# Altium schematic sheet schematic.SchDoc
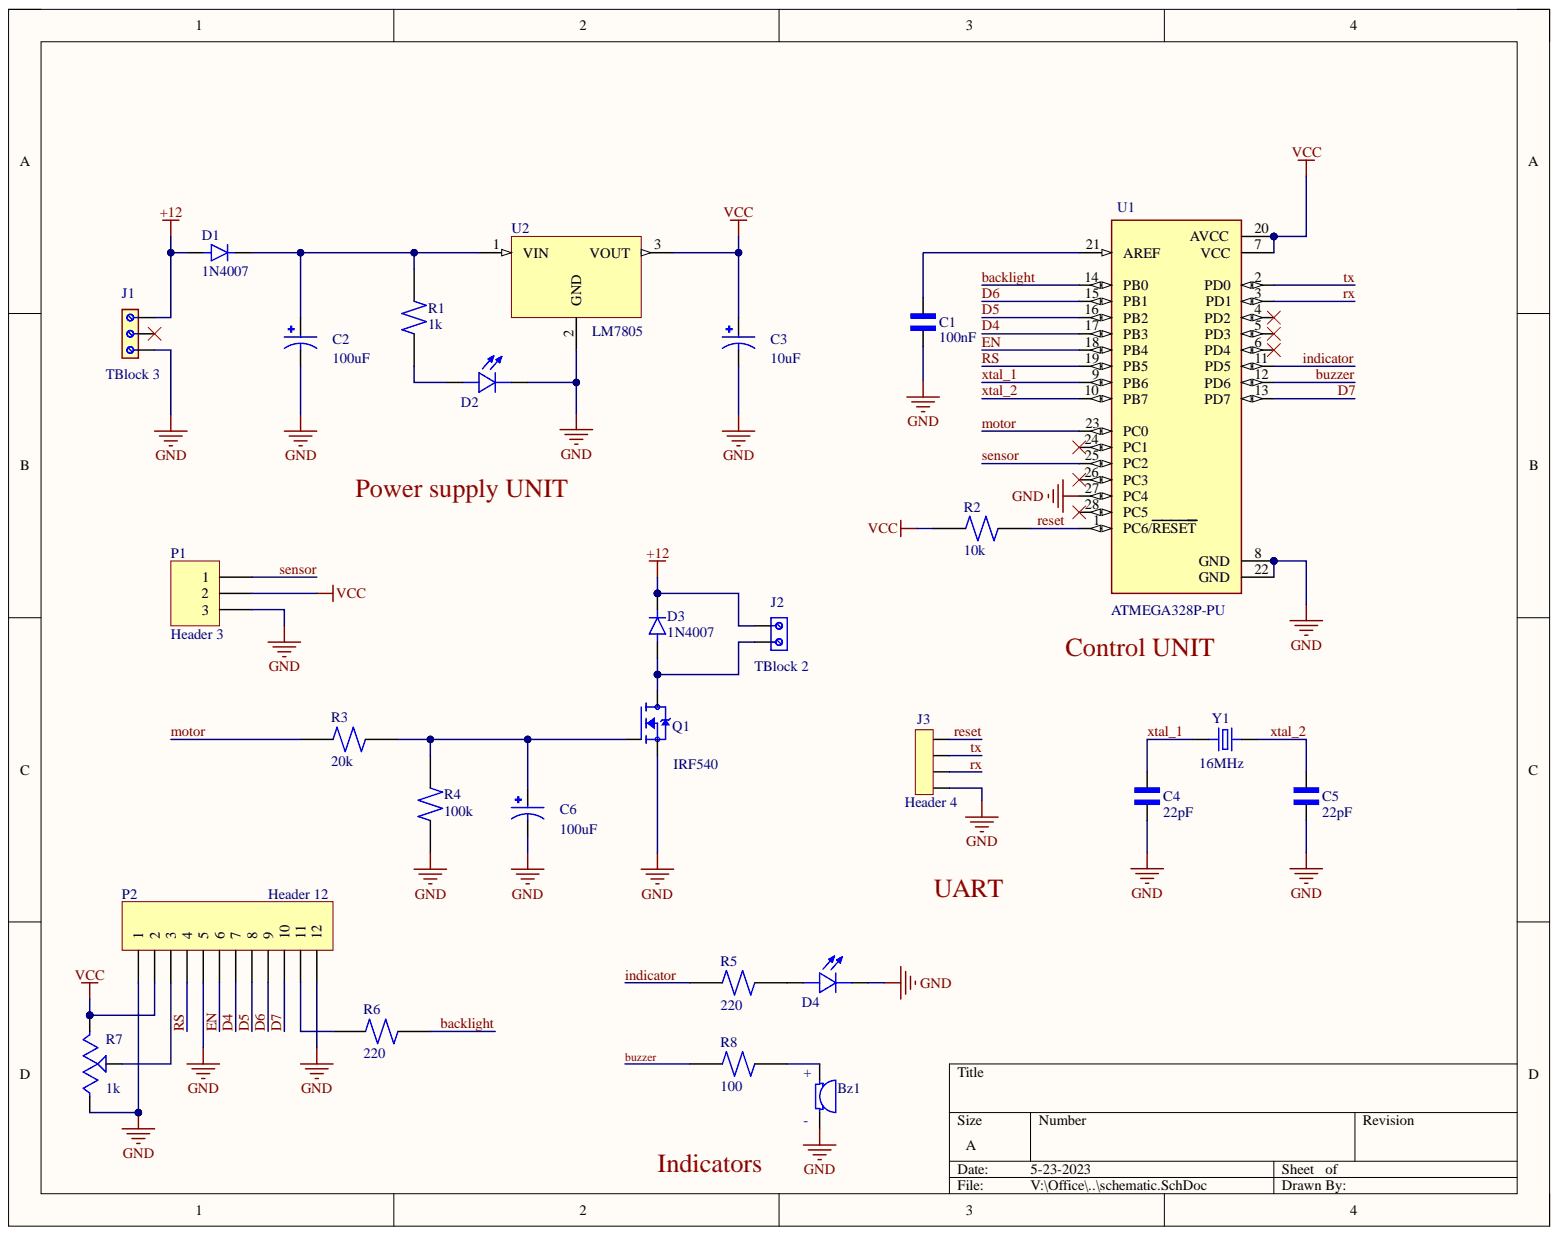
[diagram p0: schematic.SchDoc]

## Parts
Bz1  AI-1223-TWT-12V-R  [XDCR_AI-1223-TWT-12V-R]  (value not drawn)
C1  100nF  [CAPRB500W50L450T300H550]
C2  100uF  [CAPPRD500W50D500H1100]
C3  10uF  [CAPPRD500W50D500H1100]
C4  22pF  [CAPRB500W50L450T300H550]
C5  22pF  [CAPRB500W50L450T300H550]
C6  100uF  [CAPPRD500W50D500H1100]
D1  1N4007  [DIOAD1060W80L520D270]
D2  L08R5000Q1  [LEDRD254W57D500H1070]  (value not drawn)
D3  1N4007  [DIOAD1060W80L520D270]
D4  L08R5000Q1  [LEDRD254W57D500H1070]  (value not drawn)
J1  TBlock 3  [Terminal block - 3Pins]
J2  TBlock 2  [691111710002]
J3  Header 4  [SULLINS_PPTC041LFBN-RC]
P1  Header 3  [HDR1X3]
P2  Header 12  [HDR1X12]
Q1  IRF540  [TO254P1052X465X1989-3]
R1  1k  [RESAD1100W55L680D260]
R2  10k  [RESAD1100W55L680D260]
R3  20k  [RESAD1100W55L680D260]
R4  100k  [RESAD1100W55L680D260]
R5  220  [RESAD1100W55L680D260]
R6  220  [RESAD1100W55L680D260]
R7  1k  [TRIM_3306F-1-105]
R8  100  [RESAD1100W55L680D260]
U1  ATMEGA328P-PU  [DIP794W46P254L2967H457Q28B]
U2  LM7805  [TO255P1020X450X1968-3]
Y1  16MHz  [XTAL_ECS-160-20-4X]

## Nets
+12: D1.A D3.C J1.3 J2.1
GND: Bz1.N C1.2 C2.N C3.N C4.2 C5.2 C6.N D2.C D4.C J1.1 J3.4 P1.3 P2.1 P2.5 P2.12 Q1.3 R4.1 R7.1 U1.8(GND) U1.22(GND) U1.27(PC4) U2.2
VCC: C3.P P1.2 P2.2 R2.2 R7.3 U1.7(VCC) U1.20(AVCC) U2.3
backlight: R6.2 U1.14(PB0)
buzzer: R8.2 U1.12(PD6)
D4: P2.7 U1.17(PB3)
D5: P2.8 U1.16(PB2)
D6: P2.9 U1.15(PB1)
D7: P2.10 U1.13(PD7)
EN: P2.6 U1.18(PB4)
indicator: R5.2 U1.11(PD5)
motor: R3.2 U1.23(PC0)
reset: J3.1 R2.1 U1.1(PC6/RESET)
RS: P2.4 U1.19(PB5)
rx: J3.3 U1.3(PD1)
sensor: P1.1 U1.25(PC2)
tx: J3.2 U1.2(PD0)
xtal_1: C4.1 U1.9(PB6) Y1.1
xtal_2: C5.1 U1.10(PB7) Y1.2
NetBz1_P: Bz1.P R8.1
NetC1_1: C1.1 U1.21(AREF)
NetC2_P: C2.P D1.C R1.2 U2.1
NetC6_P: C6.P Q1.1 R3.1 R4.2
NetD2_A: D2.A R1.1
NetD3_A: D3.A J2.2 Q1.2
NetD4_A: D4.A R5.1
NetP2_3: P2.3 R7.2
NetP2_11: P2.11 R6.1

Not connected: J1 2; U1 4(PD2) 5(PD3) 6(PD4) 24(PC1) 26(PC3) 28(PC5)

## Library data of the parts
Bz1 = Buzzer: ELECTRO-MECHANICAL AUDIO INDICATOR; MF: PUI Audio,; Availability: In Stock; Component Kind: Standard; MP: AI-1223-TWT-12V-R; Package: 2225 PUI Audio; Price: None; Purchase-URL: https://pricing.snapeda.com/search/part/AI-1223-TWT-12V-R/?ref=eda; Library Name: Installed library.IntLib
C1 C4 C5 = Ceramic capacitor: 2200 pF Â±10% 250V Ceramic Capacitor X7R Radial; MF: TDK; MP: FG24X7R2E222KNT06; Check_prices: https://www.snapeda.com/parts/FG24X7R2E222KNT06/TDK/view-part/?ref=eda; Component Kind: Standard; Digi-Key_Part_Number: 445-173386-1-ND; Package: Radial TDK; Purchase-URL: https://pricing.snapeda.com/search/part/FG24X7R2E222KNT06/?ref=eda; SnapEDA_Link: https://www.snapeda.com/parts/FG24X7R2E222KNT06/TDK/view-part/?ref=snap; Library Name: Installed library.IntLib
C2 C3 C6 = Electrolytic capacitor: FS-A; MF: {'manufacturer': u'Panasonic'}; MP: EEUFS0J221B; Availability: Unavailable; Component Kind: Standard; Package: None; Price: None; Library Name: Installed library.IntLib
D1 D3 = 1N4007: Diode Switching 1KV 1A 2-Pin DO-41; Availability: Unavailable; Component Kind: Standard; Library Name: Components.IntLib; MP: 1N4007; Package: None; Price: None
D2 D4 = LED: LED, Orange, Through Hole, T-1 3/4 (5mm), 30 mA, 2.1 V, 635 nm; MF: {'manufacturer': u'LED Technology'}; Availability: Unavailable; Component Kind: Standard; MP: L08R5000Q1; Package: None; Price: None; Library Name: Installed library.IntLib
J1 = 3Pins Terminal block: 5.08 MM TERMINAL BLOCK;; MF: Wurth Electronics; MP: 691216510003S; Availability: In Stock; Check_prices: https://www.snapeda.com/parts/691216510003S/Wurth+Electronics+Inc/view-part/?ref=eda; Component Kind: Standard; Package: None; Price: None; Purchase-URL: https://pricing.snapeda.com/search/part/691216510003S/?ref=eda; SnapEDA_Link: https://www.snapeda.com/parts/691216510003S/Wurth+Electronics+Inc/view-part/?ref=snap; Library Name: Installed library.IntLib
J2 = Terminal Block - 2Pins: 2 Position Wire to Board Terminal Block Horizontal with Board 0.197 _5.00mm_ Through Hole; MF: Wurth Electronics; Availability: Unavailable; Component Kind: Standard; MP: 691111710002; Package: None; Price: None; SnapEDA_Link: https://www.snapeda.com/parts/691111710002/Wurth%20Electronics%20Inc./view-part/1195092/?ref=snap; Library Name: Installed library.IntLib
J3 = Female header - 4Pins: 4 Position Header Connector Through Hole; MF: Sullins Connector; MP: PPTC041LFBN-RC; Availability: Warning; Check_prices: https://www.snapeda.com/parts/PPTC041LFBN-RC/Sullins%20Connector%20Solutions/view-part/285917/?ref=eda; Component Kind: Standard; Package: None; Price: None; Purchase-URL: https://pricing.snapeda.com/search/part/PPTC041LFBN-RC/?ref=eda; SnapEDA_Link: https://www.snapeda.com/parts/PPTC041LFBN-RC/Sullins%20Connector%20Solutions/view-part/285917/?ref=snap; Library Name: Installed library.IntLib
P1 = Header 3: Header, 3-Pin; Component Kind: Standard; LatestRevisionDate: 17-Jul-2002; LatestRevisionNote: Re-released for DXP Platform.; Library Name: Miscellaneous Connectors.IntLib; Signal Integrity: Connector; Publisher: Altium Limited
P2 = Header 12: Header, 12-Pin; Component Kind: Standard; LatestRevisionDate: 17-Jul-2002; LatestRevisionNote: Re-released for DXP Platform.; Library Name: Miscellaneous Connectors.IntLib; Signal Integrity: Connector; Publisher: Altium Limited
Q1 = IRF540: N-Channel 100V 22A (Tc) 85W (Tc) Through Hole TO-220AB; Availability: Unavailable; Component Kind: Standard; MP: IRF540; Package: None; Price: None; Library Name: Installed library.IntLib
R1-R6 R8 = Resistor (0.25W): (MFR-25FBF52-10K) 10,2 kOhm bei 1% 0,25W, 1/4W Durchbohrlochwiderstand Axial Metal Film; MF: Yageo; Component Kind: Standard; Digi-Key_Part_Number: 10.2KXBK-ND; MP: MFR-25FBF52-10K; Package: Axial Yageo; Purchase-URL: https://pricing.snapeda.com/search/part/MFR-25FBF52-10K/?ref=eda; SnapEDA_Link: https://www.snapeda.com/parts/MFR-25FBF52-10K/Yageo/view-part/424333/?ref=snap; Library Name: Installed library.IntLib
R7 = Potentiometer1: (3306F-1-105) 1 MOhms 0.2W, 1/5W PC Pins Through Hole Trimmer Potentiometer Cermet 1 Turn Top Adjustment; MF: Bourns; Availability: Good; Check_prices: https://www.snapeda.com/parts/3306F-1-105/Bourns%20-%20J.W.%20Miller/view-part/862876/?ref=eda; Component Kind: Standard; MP: 3306F-1-105; Package: 3306F Bourns; Price: None; Purchase-URL: https://pricing.snapeda.com/search/part/3306F-1-105/?ref=eda; SnapEDA_Link: https://www.snapeda.com/parts/3306F-1-105/Bourns%20-%20J.W.%20Miller/view-part/862876/?ref=snap; Library Name: Installed library.IntLib
U1 = ATMEGA328P-PU: ATmega Series 20 MHz 32 KB Flash 2 KB SRAM 8-Bit Microcontroller - DIP-28; Availability: Unavailable; Component Kind: Standard; MP: ATMEGA328P-PU; Package: None; Price: None; Library Name: Installed library.IntLib
U2 = LM78__: MP: LM7805CT/NOPB; Availability: Unavailable; Component Kind: Standard; Library Name: Voltage regulator.IntLib; Package: None; Price: None
Y1 = Crystal oscilator: CRYSTAL T/H; MF: {'manufacturer': u'ECS Inc.'}; MP: ECS-160-S-4; Availability: Unavailable; Component Kind: Standard; Package: None; Price: None; Library Name: Installed library.IntLib
